# Altium schematic sheet USER.SchDoc
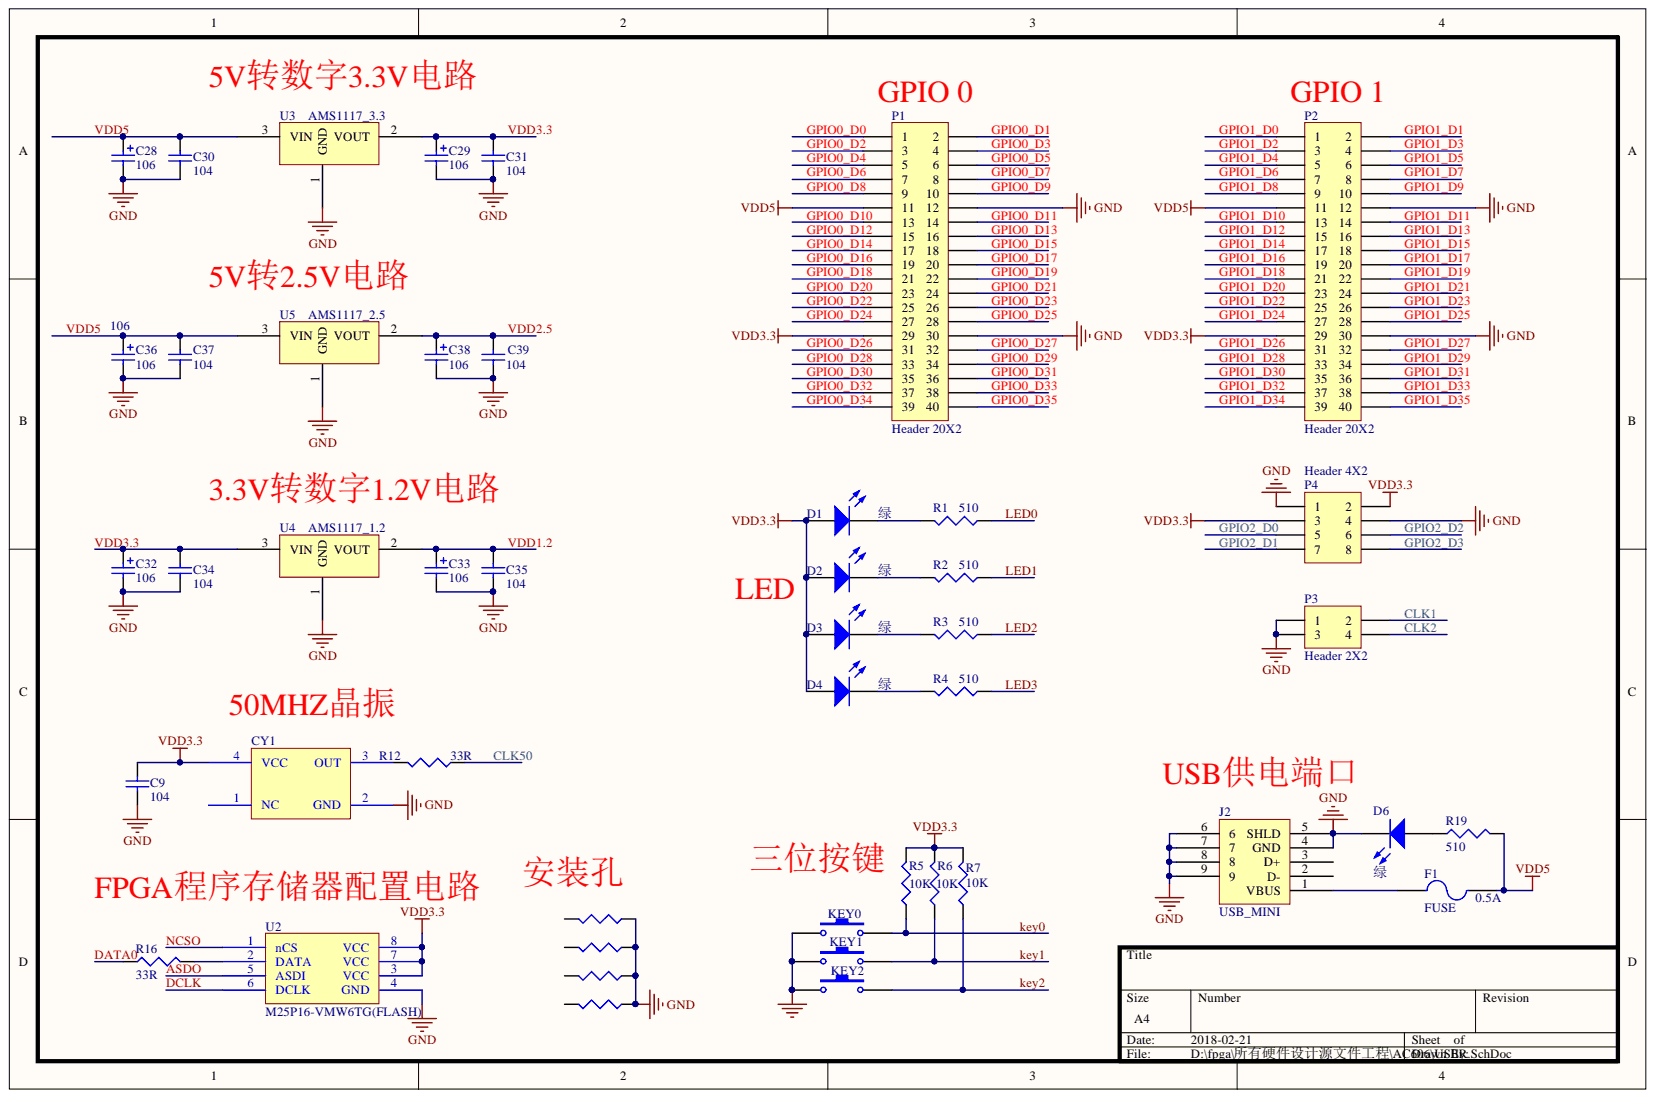
[diagram p0: USER.SchDoc]

## Parts
C9  104  [0603C]
C28  106  [1206]
C29  106  [1206]
C30  104  [0603C]
C31  104  [0603C]
C32  106  [1206]
C33  106  [1206]
C34  104  [0603C]
C35  104  [0603C]
C36  106  [1206]
C37  104  [0603C]
C38  106  [1206]
C39  104  [0603C]
CY1  50M 晶振  [EXATL]  (value not drawn)
D1  绿  [0603 LED]
D2  绿  [0603 LED]
D3  绿  [0603 LED]
D4  绿  [0603 LED]
D6  绿  [0603 LED]
F1  FUSE  value 0.5A  [FUSE1812]
GND1  RES  [Via]  (value not drawn)
GND2  RES  [Via]  (value not drawn)
GND3  RES  [Via]  (value not drawn)
GND4  RES  [Via]  (value not drawn)
J2  USB_MINI  [micro_USB_平口 4固定脚无边]
KEY0  SW-PB  [key_2]  (value not drawn)
KEY1  SW-PB  [key_2]  (value not drawn)
KEY2  SW-PB  [key_2]  (value not drawn)
P1  Header 20X2  [IDC-40J]
P2  Header 20X2  [IDC-40J]
P3  Header 2X2  [HDR2X2]
P4  Header 4X2  [HDR2X4]
R1  510  [0603R]
R2  510  [0603R]
R3  510  [0603R]
R4  510  [0603R]
R5  10K  [0603R]
R6  10K  [0603R]
R7  10K  [0603R]
R12  33R  [0603R]
R16  33R  [0603R]
R19  510  [0603R]
U2  M25P16-VMW6TG(FLASH)  [epcs4]
U3  AMS1117_3.3  [SOT-223-1]
U4  AMS1117_1.2  [SOT-223-1]
U5  AMS1117_2.5  [SOT-223-1]

## Nets
GND: C9.1 C28.2 C29.2 C30.1 C31.1 C32.2 C33.2 C34.1 C35.1 C36.2 C37.1 C38.2 C39.1 CY1.2(GND) D6.2 GND1.1 GND2.1 GND3.1 GND4.1 J2.4(GND) J2.5(SHLD) J2.6 J2.7 J2.8 J2.9 KEY0.1 KEY1.1 KEY2.1 P1.12 P1.30 P2.12 P2.30 P3.1 P3.3 P4.1 P4.4 U2.4(GND) U3.1 U4.1 U5.1
ASDO: U2.5(ASDI)
CLK1: P3.2
CLK2: P3.4
CLK50: R12.1
DATA0: R16.1
DCLK: U2.6(DCLK)
GPIO0_D0: P1.1
GPIO0_D1: P1.2
GPIO0_D2: P1.3
GPIO0_D3: P1.4
GPIO0_D4: P1.5
GPIO0_D5: P1.6
GPIO0_D6: P1.7
GPIO0_D7: P1.8
GPIO0_D8: P1.9
GPIO0_D9: P1.10
GPIO0_D10: P1.13
GPIO0_D11: P1.14
GPIO0_D12: P1.15
GPIO0_D13: P1.16
GPIO0_D14: P1.17
GPIO0_D15: P1.18
GPIO0_D16: P1.19
GPIO0_D17: P1.20
GPIO0_D18: P1.21
GPIO0_D19: P1.22
GPIO0_D20: P1.23
GPIO0_D21: P1.24
GPIO0_D22: P1.25
GPIO0_D23: P1.26
GPIO0_D24: P1.27
GPIO0_D25: P1.28
GPIO0_D26: P1.31
GPIO0_D27: P1.32
GPIO0_D28: P1.33
GPIO0_D29: P1.34
GPIO0_D30: P1.35
GPIO0_D31: P1.36
GPIO0_D32: P1.37
GPIO0_D33: P1.38
GPIO0_D34: P1.39
GPIO0_D35: P1.40
GPIO1_D0: P2.1
GPIO1_D1: P2.2
GPIO1_D2: P2.3
GPIO1_D3: P2.4
GPIO1_D4: P2.5
GPIO1_D5: P2.6
GPIO1_D6: P2.7
GPIO1_D7: P2.8
GPIO1_D8: P2.9
GPIO1_D9: P2.10
GPIO1_D10: P2.13
GPIO1_D11: P2.14
GPIO1_D12: P2.15
GPIO1_D13: P2.16
GPIO1_D14: P2.17
GPIO1_D15: P2.18
GPIO1_D16: P2.19
GPIO1_D17: P2.20
GPIO1_D18: P2.21
GPIO1_D19: P2.22
GPIO1_D20: P2.23
GPIO1_D21: P2.24
GPIO1_D22: P2.25
GPIO1_D23: P2.26
GPIO1_D24: P2.27
GPIO1_D25: P2.28
GPIO1_D26: P2.31
GPIO1_D27: P2.32
GPIO1_D28: P2.33
GPIO1_D29: P2.34
GPIO1_D30: P2.35
GPIO1_D31: P2.36
GPIO1_D32: P2.37
GPIO1_D33: P2.38
GPIO1_D34: P2.39
GPIO1_D35: P2.40
GPIO2_D0: P4.5
GPIO2_D1: P4.7
GPIO2_D2: P4.6
GPIO2_D3: P4.8
KEY0: KEY0.2 R5.1
KEY1: KEY1.2 R6.1
KEY2: KEY2.2 R7.1
LED0: R1.1
LED1: R2.1
LED2: R3.1
LED3: R4.1
NCSO: U2.1(nCS)
VDD1.2: C33.1 C35.2 U4.2
VDD2.5: C38.1 C39.2 U5.2
VDD3.3: C9.2 C29.1 C31.2 C32.1 C34.2 CY1.4(VCC) D1.1 D2.1 D3.1 D4.1 P1.29 P2.29 P4.2 P4.3 R5.2 R6.2 R7.2 U2.3(VCC) U2.7(VCC) U2.8(VCC) U3.2 U4.3
VDD5: C28.1 C30.2 C36.1 C37.2 F1.1 P1.11 P2.11 R19.2 U3.3 U5.3
NetCY1_3: CY1.3(OUT) R12.2
NetD1_2: D1.2 R1.2
NetD2_2: D2.2 R2.2
NetD3_2: D3.2 R3.2
NetD4_2: D4.2 R4.2
NetD6_1: D6.1 R19.1
NetF1_2: F1.2 J2.1(VBUS)
NetR16_2: R16.2 U2.2(DATA)

Not connected: CY1 1(NC); GND1 2; GND2 2; GND3 2; GND4 2; J2 2(D-) 3(D+)

## Library data of the parts
C9 C30 C31 C34 C35 C37 C39 = Cap Semi: Capacitor (Semiconductor SIM Model); 贴片和直插: SMT; Component Kind: Standard; Library Name: NEW.SchLib; PackageDescription: Chip Capacitor; PackageReference: C0603; Value: 104
C28 C29 C32 C33 C36 C38 = Cap Pol3: Polarized Capacitor (Surface Mount); 贴片和直插: SMT; Component Kind: Standard; Library Name: NEW.SchLib; PackageDescription: Chip Capacitor; PackageReference: C1206; Value: 106
CY1 = EXALT: 贴片和直插: SMT; Component Kind: Standard; PackageDescription: 贴片晶振; PackageReference: 7050; Value: 50M; Library Name: MCA.SCHLIB
D1-D4 = LED2: Typical RED, GREEN, YELLOW, AMBER GaAs LED; Value: LED_GREEN; 贴片和直插: SMT; Component Kind: Standard; Library Name: Miscellaneous Devices.IntLib; PackageDescription: SM LED; 2 Flat Leads; PackageReference: 0603
D6 = LED3: Typical BLUE SiC LED; Value: LED_GREEN; 贴片和直插: SMT; Component Kind: Standard; Library Name: Miscellaneous Devices.IntLib; PackageDescription: SM LED; 2 Flat Leads; PackageReference: 0603
F1 = Fuse 2: 贴片和直插: SMT; Component Kind: Standard; Description: Fuse; Library Name: Miscellaneous Devices.IntLib; PackageDescription: Thru-Hole; 2 Leads; PackageReference: PIN-W2/E2.8
J2 = USB_MINI: Value: USB Mini; 贴片和直插: SMT; Component Kind: Standard; Library Name: NEW.SchLib; PackageDescription: USB迷你接口，贴片; PackageReference: USB Mini
KEY0-KEY2 = SW-PB: Switch; Value: 贴片轻触按键; 贴片和直插: SMT; Component Kind: Standard; Library Name: Miscellaneous Devices.IntLib; PackageDescription: Switch; 2 Leads; PackageReference: SPST-2
P1 P2 = Header 20X2: Header, 20-Pin, Dual row; Value: IDC40; 贴片和直插: DIP; Component Kind: Standard; Library Name: Miscellaneous Connectors.IntLib; PackageDescription: IDC40, 2.54mm间距，20*2; PackageReference: IDC40
P3 = Header 2X2: Header, 2-Pin, Dual row; Component Kind: Standard; LatestRevisionDate: 17-Jul-2002; LatestRevisionNote: Re-released for DXP Platform.; Library Name: Miscellaneous Connectors.IntLib; Publisher: Altium Limited
P4 = Header 4X2: Header, 4-Pin, Dual row; Component Kind: Standard; LatestRevisionDate: 17-Jul-2002; LatestRevisionNote: Re-released for DXP Platform.; Library Name: Miscellaneous Connectors.IntLib; Publisher: Altium Limited
R1-R7 R12 R16 R19 = RES: 贴片和直插: SMT; Component Kind: Standard; PackageReference: R0603
  R1-R4 R19: Library Name: NEW.SchLib; PackageDescription: Chip Resistor; Value: 510
  R5-R7: Library Name: NEW.SchLib; PackageDescription: Chip Resistor; Value: 10K
  R12: Library Name: MySchlib.SchLib; PackageDescription: R0603; Value: 33R
  R16: Library Name: NEW.SchLib; PackageDescription: R0603; Value: 33R
U2 = EPCS4: Value: W25Q16; 贴片和直插: SMT; Component Kind: Standard; PackageDescription: 208mil; PackageReference: SO8W; Library Name: MCA.SCHLIB
U3 = AMS1117: Value: AMS117-3.3; 贴片和直插: SMT; Component Kind: Standard; Library Name: NEW.SchLib; PackageDescription: 4脚，6.7*7.3mm; PackageReference: SOT223
U4 = AMS1117: Value: AMS1117-1.2; 贴片和直插: SMT; Component Kind: Standard; Library Name: NEW.SchLib; PackageDescription: 4脚，6.7*7.3mm; PackageReference: SOT223
U5 = AMS1117: Value: AMS1117-2.5; 贴片和直插: SMT; Component Kind: Standard; Library Name: NEW.SchLib; PackageDescription: 4脚，6.7*7.3mm; PackageReference: SOT223
GND1-GND4 = RES: Component Kind: Standard; Library Name: NEW.SchLib
